# Altium schematic sheet Power.SchDoc
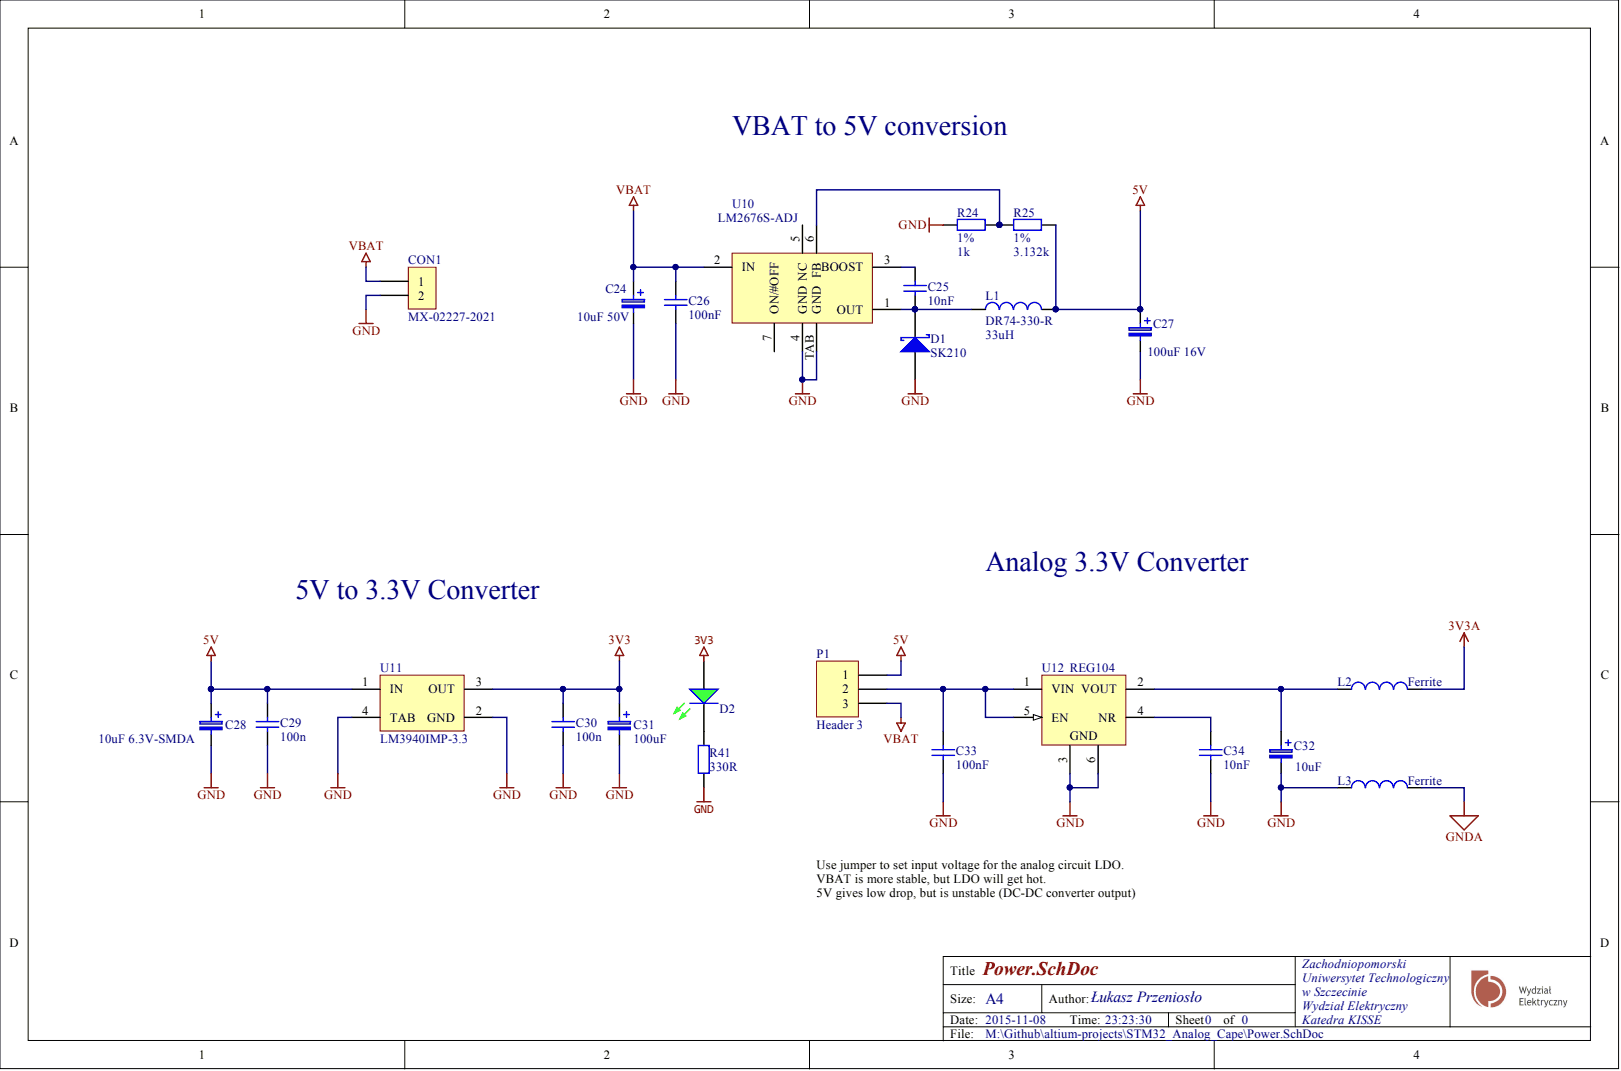
[diagram p0: Power.SchDoc]

## Parts
C24  10uF 50V  [SMDD]
C25  10nF  [CAPC1608N]
C26  100nF  [CAPC1608N]
C27  100uF 16V  [SMDD]
C28  10uF 6.3V-SMDA  [SMDA]
C29  100n  [CAPC1608N]
C30  100n  [CAPC1608N]
C31  100uF  [SMDB]
C32  10uF  [SMDA]
C33  100nF  [CAPC1608N]
C34  10nF  [CAPC1608N]
CON1  MX-02227-2021  [MX-02227-2021]
D1  SK210  [SMB]
D2  LL-S172GC-2A  [CD2012-0805]  (value not drawn)
L1  DR74-330-R  value 33uH  [DR74 Series]
L2  Ferrite  [inductor_1206]
L3  Ferrite  [inductor_1206]
P1  Header 3  [HEADER_3]
R24  1k  Tolerance: 1%  [RESC1608N]
R25  3.132k  Tolerance: 1%  [RESC1608N]
R41  330R  [RESC1608N]
U10  LM2676S-ADJ  [TO-263-7]
U11  LM3940IMP-3.3  [MP04A_N]
U12  REG104  [DCQ6_L]

## Nets
3V3: C30.2 C31.1 D2.1 U11.3(OUT)
3V3A: L2.2
5V: C27.1 C28.1 C29.2 L1.2 P1.1 R25.2 U11.1(IN)
GND: C24.2 C26.1 C27.2 C28.2 C29.1 C30.1 C31.2 C32.2 C33.1 C34.1 CON1.2 D1.1 L3.1 R24.1 R41.2 U10.4(GND) U10.TAB(GND) U11.2(GND) U11.4(TAB) U12.3 U12.6
GNDA: L3.2
VBAT: C24.1 C26.2 CON1.1 P1.3 U10.2(IN)
NetC25_1: C25.1 D1.2 L1.1 U10.1(OUT)
NetC25_2: C25.2 U10.3(BOOST)
NetC32_1: C32.1 L2.1 U12.2(VOUT)
NetC33_2: C33.2 P1.2 U12.1(VIN) U12.5(EN)
NetC34_2: C34.2 U12.4(NR)
NetD2_2: D2.2 R41.1
NetR24_2: R24.2 R25.1 U10.6(FB)

Not connected: U10 5(NC) 7(ON/#OFF)

## Library data of the parts
C24 C28 C31 = Capacitor Tantalum: Component Kind: Standard; Description: Capacitor Tantalum; Library Name: Discrete.SchLib; Signal Integrity: Cap; Simulation: CAP
  C24: Value: 10uF 50V
  C28: Value: 10uF 6.3V-SMDA
  C31: Value: 100uF
C25 C26 C33 C34 = Capacitor: Component Kind: Standard; Description: Capacitor; Library Name: Discrete.SchLib; Signal Integrity: Cap; Simulation: CAP
  C25 C34: Value: 10nF
  C26 C33: Value: 100nF
C27 = 10uF 35V-SMDD: Polarized Capacitor (Surface Mount); Value: 10uF; Component Kind: Standard; Distributor: tme.pl; Distributor Order Code: TC 10/35-SMDD; PackageReference: SMDD; Signal Integrity: Cap Pol; Simulation: CAP; tme.pl: TC 10/35-SMDD; Library Name: Mechatronic.SchLib
C29 C30 = Cap: Capacitor; Component Kind: Standard; LatestRevisionDate: 17-Jul-2002; LatestRevisionNote: Re-released for DXP Platform.; Library Name: Miscellaneous Devices.IntLib; Published: 8-Jun-2000; Signal Integrity: Cap; Simulation: CAP; Publisher: Altium Limited
C32 = 100uF 6.3V-SMDB: Polarized Capacitor (Surface Mount); Component Kind: Standard; Distributor: tme.pl; Distributor Order Code: TC 100/6.3-SMDB; farnell: 1135048; PackageReference: SMDB; Signal Integrity: Cap Pol; Simulation: CAP; tme.pl: TC 100/6.3-SMDB; Value: 10uF; Library Name: Mechatronic.SchLib
CON1 = MX-02227-2021: Pith 2.54mm, 2-pin; Component Kind: Standard; ComponentLink1Description: Manufacturer Link; ComponentLink2Description: Datasheet; Distributor: tme.pl; Library Name: Connectors.SchLib; tme.pl: MX-6410-03A
D1 = MBRS340T3: Schottky Barrier Rectifier,  40V, 3A; Component Kind: Standard; PackageReference: SMC; Signal Integrity: D Schottky; Simulation: SKYDIODE; tme.pl: MBRS340T3; Library Name: Mechatronic.SchLib
D2 = Led Green: Typical GREEN LED; Manufacturer: Lucky Light; Component Kind: Standard; Library Name: Discrete.SchLib; Value: LL-S172GC-2A
L1 = DR74-330-R: elfa.se: 58-101-14; Component Kind: Standard; ComponentLink1Description: Manufacturer Link; ComponentLink2Description: Datasheet; Distributor: tme.pl; PackageDocument: Sep-1998; Published: 8-Jun-2000; Library Name: Mechatronic.SchLib; Publisher: Altium Limited
L2 L3 = Inductor: Code_IEC: 1005; Value: 10mH; Code_JEITA: 0402; Component Kind: Standard; Description: Inductor; LatestRevisionDate: 29-May-2009; LatestRevisionNote: IPC-7351 Footprint Added.; Library Name: Miscellaneous Devices.IntLib; PackageDescription: Chip Inductor; PackageReference: 0402-A; Published: 8-Jun-2000; Signal Integrity: Inductor; Simulation: INDUCTOR; Publisher: Altium Limited
P1 = Header 3: Header, 3-Pin; Component Kind: Standard; LatestRevisionDate: 17-Jul-2002; LatestRevisionNote: Re-released for DXP Platform.; Library Name: Miscellaneous Connectors.IntLib; Signal Integrity: Connector; Publisher: Altium Limited
R24 R25 = Resistor: Power: 0W; Component Kind: Standard; Description: Resistor; Library Name: Discrete.SchLib; Signal Integrity: Res; Simulation: RESISTOR
  R24: Value: 1k
  R25: Value: 3.132k
R41 = Resistor: Power: 0W; Tolerance: 5%; Component Kind: Standard; Description: Resistor; Library Name: Discrete.SchLib; Signal Integrity: Res; Simulation: RESISTOR; Value: 330R
U10 = LM2676S-ADJ: SIMPLE SWITCHER High Efficiency 3A Step-Down Voltage Regulator; elfa.se: 73-068-81; Component Kind: Standard; ComponentLink1Description: Manufacturer Link; ComponentLink1URL: http://www.national.com/; ComponentLink2Description: Datasheet; ComponentLink2URL: http://www.national.com/ds.cgi/LM/LM2676.pdf; Distributor: tme.pl; Library Name: Mechatronic.SchLib
U11 = LM3940IMP-3.3: 1A Low Dropout Regulator for 5V to 3.3V Conversion, 4-pin SOT-223; Manufacturer: National Semiconductor; CompType: LDO IC; PackageTopMarking: XYTT*L52B; PowerWise: N; Code_IPC: SOT223-4; Code_JEDEC: TO-261-AA; Component Kind: Standard; ComponentLink1Description: LM3940 Datasheet; ComponentLink1URL: http://www.national.com/ds/LM/LM3940.pdf; ComponentLink2Description: LM3940 Web Page; ComponentLink2URL: http://www.national.com/pf/LM/LM3940.html; ComponentLink3Description: MP04A Package Page; ComponentLink3URL: http://www.national.com/packaging/folders/mp04a.html; DatasheetVersion: Jul-2007; Library Name: NSC LDO.IntLib; PackageDescription: Molded SOT-223, 6.50 x 3.56 x 1.6mm Body, 4 Lead, 2.3mm Pitch; PackageReference: MP04A; PackageVersion: revB, Apr-2003; PartNumber: LM3940IMP-3.3; PinCount: 4; Published: 4-Dec-2008; RoHS: N; Supplier 1: Farnell; Supplier Part Number 1: 9779280; Publisher: Altium Limited
U12 = REG104: Single Output Fast Transient Response LDO, 1 A, Fixed 3.3 V Output, 2.1 to 15 V Input, 6-pin SOT-223 (DCQ), -40 to 85 degC, Green (RoHS & no Sb/Br); Manufacturer: Texas Instruments; PartNumber: REG104GA-3.3/2K5G4; Accuracy(%): 2; Fixed Output Options(V): 3.3; Iout(Max)(A): 1; Iq(Typ)(mA): 0.6; SubFamily: Single Channel LDO; Vdo(Typ)(mV): 230; Vin(Max)(V): 15; Vin(Min)(V): 2.1; Component Kind: Standard; ComponentLink1Description: Manufacturer URL; ComponentLink1URL: http://www.ti.com/; ComponentLink2Description: Datasheet; ComponentLink2URL: http://www.ti.com/general/docs/lit/getliterature.tsp?genericPartNumber=REG104-33&fileType=pdf; ComponentLink3Description: Package Specification; ComponentLink3URL: http://www.ti.com/litv/pdf/mpds098c; DatasheetVersion: SBVS025G; Eco-Plan: Green (RoHS & no Sb/Br); Mounting Technology: Surface Mount; PackageDescription: 6-Pin Plastic Small Outline, Body 3.5 x 6.5 mm, Pitch 1.27 mm; PackageReference: DCQ6; PackageVersion: 4202109/D; Vout Adj(Max)(V): 3.3; Vout Adj(Min)(V): 3.3; Library Name: my_various_devices.SchLib
